# Altium schematic sheet W5500.SchDoc
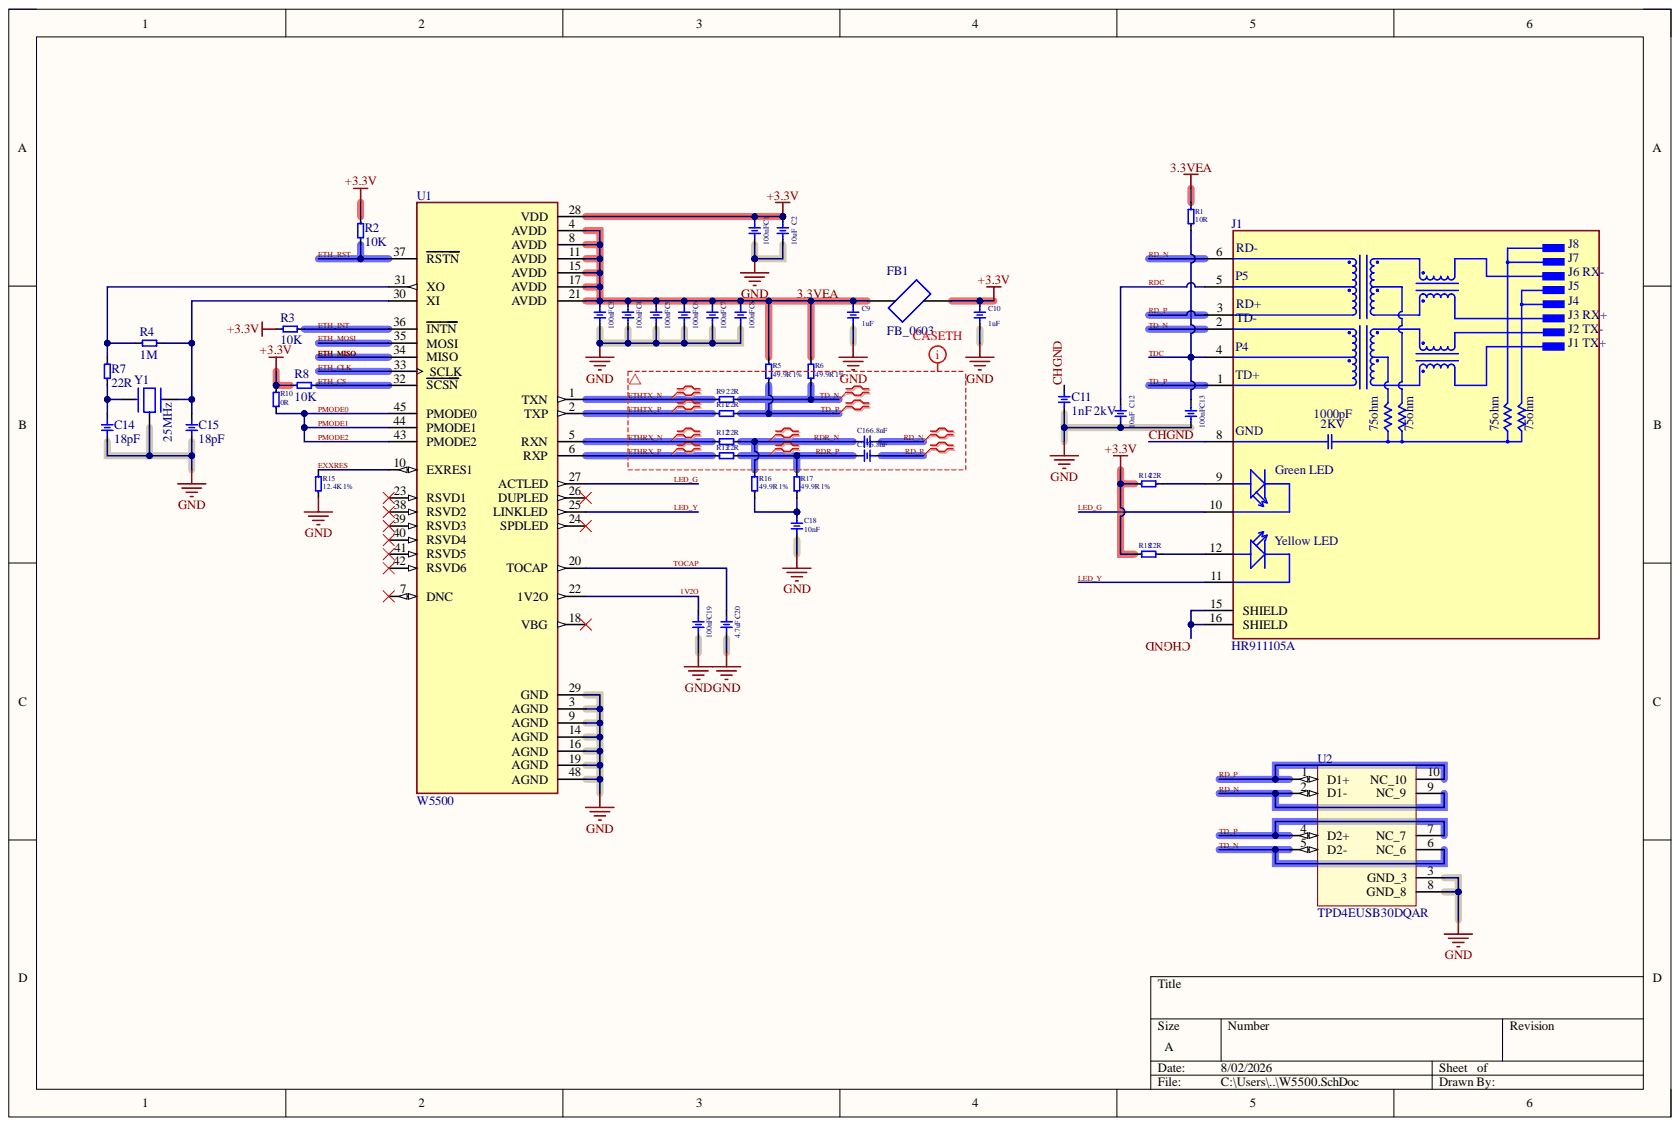
[diagram p0: W5500.SchDoc]

## Parts
C1  100nF  [CAP_0402]
C2  10uF  [CAP_0402]
C3  100nF  [CAP_0402]
C4  100nF  [CAP_0402]
C5  100nF  [CAP_0402]
C6  100nF  [CAP_0402]
C7  100nF  [CAP_0402]
C8  100nF  [CAP_0402]
C9  1uF  [CAP_0402]
C10  1uF  [CAP_0402]
C11  1nF 2kV  [CAP_1210]
C12  10nF  [CAP_0402]
C13  100nF  [CAP_0402]
C14  18pF  [CAP_0402]
C15  18pF  [CAP_0402]
C16  6.8nF  [CAP_0402]
C17  6.8nF  [CAP_0402]
C18  10nF  [CAP_0402]
C19  100nF  [CAP_0402]
C20  4.7uF  [CAP_0402]
FB1  FB_0603  [FB_0603]
J1  HR911105A  [HANRUN_HR911105A]
R1  10R  [RES_0402]
R2  10K  [RES_0402]
R3  10K  [RES_0402]
R4  1M  [RES_0402]
R5  49.9R 1%  [RES_0402]
R6  49.9R 1%  [RES_0402]
R7  22R  [RES_0402]
R8  10K  [RES_0402]
R9  22R  [RES_0402]
R10  0R  [RES_0402]
R11  22R  [RES_0402]
R12  22R  [RES_0402]  (value not drawn)
R13  22R  [RES_0402]  (value not drawn)
R14  22R  [RES_0402]  (value not drawn)
R15  12.4K 1%  [RES_0402]
R16  49.9R 1%  [RES_0402]
R17  49.9R 1%  [RES_0402]
R18  22R  [RES_0402]  (value not drawn)
U1  W5500  [QFP50P900X900X160-48N]
U2  TPD4EUSB30DQAR  [IC_TPD4EUSB30DQAR]
Y1  25MHz  [Xtai_3325_4P]

## Nets
+3.3V: C1.2 C2.2 C10.2 FB1.2 R2.2 R3.1 R8.2 R10.1 R14.2 R18.2 U1.28(VDD)
GND: C1.1 C2.1 C3.1 C4.1 C5.1 C6.1 C7.1 C8.1 C9.1 C10.1 C11.1 C12.1 C13.1 C14.1 C15.1 C18.2 C19.1 C20.1 R15.2 U1.3(AGND) U1.9(AGND) U1.14(AGND) U1.16(AGND) U1.19(AGND) U1.29(GND) U1.48(AGND) U2.3(GND_3) U2.8(GND_8) Y1.4
1V2O: C19.2 U1.22(1V2O)
3.3VEA: C3.2 C4.2 C5.2 C6.2 C7.2 C8.2 C9.2 FB1.1 R1.1 R5.1 R6.1 U1.4(AVDD) U1.8(AVDD) U1.11(AVDD) U1.15(AVDD) U1.17(AVDD) U1.21(AVDD)
CHGND: C11.2 J1.8 J1.15(SHIELD) J1.16(SHIELD)
ETH_CLK: U1.33(SCLK)
ETH_CS: R8.1 U1.32(SCSN)
ETH_INT: R3.2 U1.36(INTN)
ETH_MISO: U1.34(MISO)
ETH_MOSI: U1.35(MOSI)
ETH_RST: R2.1 U1.37(RSTN)
ETHRX_N: R12.2 U1.5(RXN)
ETHRX_P: R13.2 U1.6(RXP)
ETHTX_N: R9.2 U1.1(TXN)
ETHTX_P: R11.2 U1.2(TXP)
EXXRES: R15.1 U1.10(EXRES1)
LED_G: J1.10 U1.27(ACTLED)
LED_Y: J1.11 U1.25(LINKLED)
PMODE0: R10.2 U1.43(PMODE2) U1.44(PMODE1) U1.45(PMODE0)
RD_N: C16.1 J1.6 U2.2(D1-) U2.9(NC_9)
RD_P: C17.1 J1.3(TD-) U2.1(D1+) U2.10(NC_10)
RDC: C12.2 J1.5
RDR_N: C16.2 R12.1 R16.1
RDR_P: C17.2 R13.1 R17.1
TD_N: J1.2 R6.2 R9.1 U2.5(D2-) U2.6(NC_6)
TD_P: J1.1 R5.2 R11.1 U2.4(D2+) U2.7(NC_7)
TDC: C13.2 J1.4 R1.2
TOCAP: C20.2 U1.20(TOCAP)
NetC14_2: C14.2 R7.1 Y1.1
NetC15_2: C15.2 R4.2 U1.30(XI) Y1.3
NetC18_1: C18.1 R16.2 R17.2
NetJ1_9: J1.9 R14.1
NetJ1_12: J1.12 R18.1
NetR4_1: R4.1 R7.2 U1.31(XO)

Not connected: U1 7(DNC) 18(VBG) 23(RSVD1) 24(SPDLED) 26(DUPLED) 38(RSVD2) 39(RSVD3) 40(RSVD4) 41(RSVD5) 42(RSVD6)

## Library data of the parts
C1-C10 C12-C20 = CAP_0402: Component Kind: Standard; Library Name: RQSchlib.SchLib
C11 = CAP_1210: Component Kind: Standard; Library Name: RQSchlib.SchLib
FB1 = FB_0603: Ferrite Bead | 1200 Ohm | 0603 1LN; MF: Signal Transformer; MP: FCB-0603-122A; Availability: Not in stock; Check_prices: https://www.snapeda.com/parts/FCB-0603-122A/Signal+Transformer/view-part/?ref=eda; Component Kind: Standard; Package: None; Price: None; SnapEDA_Link: https://www.snapeda.com/parts/FCB-0603-122A/Signal+Transformer/view-part/?ref=snap; Library Name: RQSchlib.SchLib
J1 = HR911105A: DIP RJ45 Connector;; MF: hanrun; Availability: Not in stock; Check_prices: https://www.snapeda.com/parts/HR911105A/HanRun/view-part/?ref=eda; Component Kind: Standard; MP: HR911105A; Package: None; Price: None; SnapEDA_Link: https://www.snapeda.com/parts/HR911105A/HanRun/view-part/?ref=snap; Library Name: RQSchlib.SchLib
R1-R18 = RES_0402: Component Kind: Standard; Library Name: RQSchlib.SchLib
U1 = W5500: Hardwired TCP/IP embedded Ethernet controller; Availability: Unavailable; Component Kind: Standard; MP: W5500; Package: None; Price: None; Library Name: RQSchlib.SchLib
U2 = TPD4EUSB30DQAR: 4-channel ESD solution for SuperSpeed (6 Gbps) USB 3.0 interface 10-USON -40 to 85; Availability: Unavailable; Component Kind: Standard; MP: TPD4EUSB30DQAR; Package: None; Price: None; Library Name: RQSchlib.SchLib
Y1 = Xtal_3325: Crystal - 48MHz Â±10ppm - 10 pF - Â±15 ppm - -30 to +85Â°C 3225 Low aging; MF: ECS Inc.; MP: ECS-480-8-33B-CWY-TR3; Check_prices: https://www.snapeda.com/parts/ECS-480-8-33B-CWY-TR3/ECS/view-part/?ref=eda; Component Kind: Standard; Package: ECS-33B ECS Inc.; SnapEDA_Link: https://www.snapeda.com/parts/ECS-480-8-33B-CWY-TR3/ECS/view-part/?ref=snap; Library Name: RQSchlib.SchLib
